# Altium schematic sheet Sheet_Power_v1.SchDoc(Sheet_Power_v1)
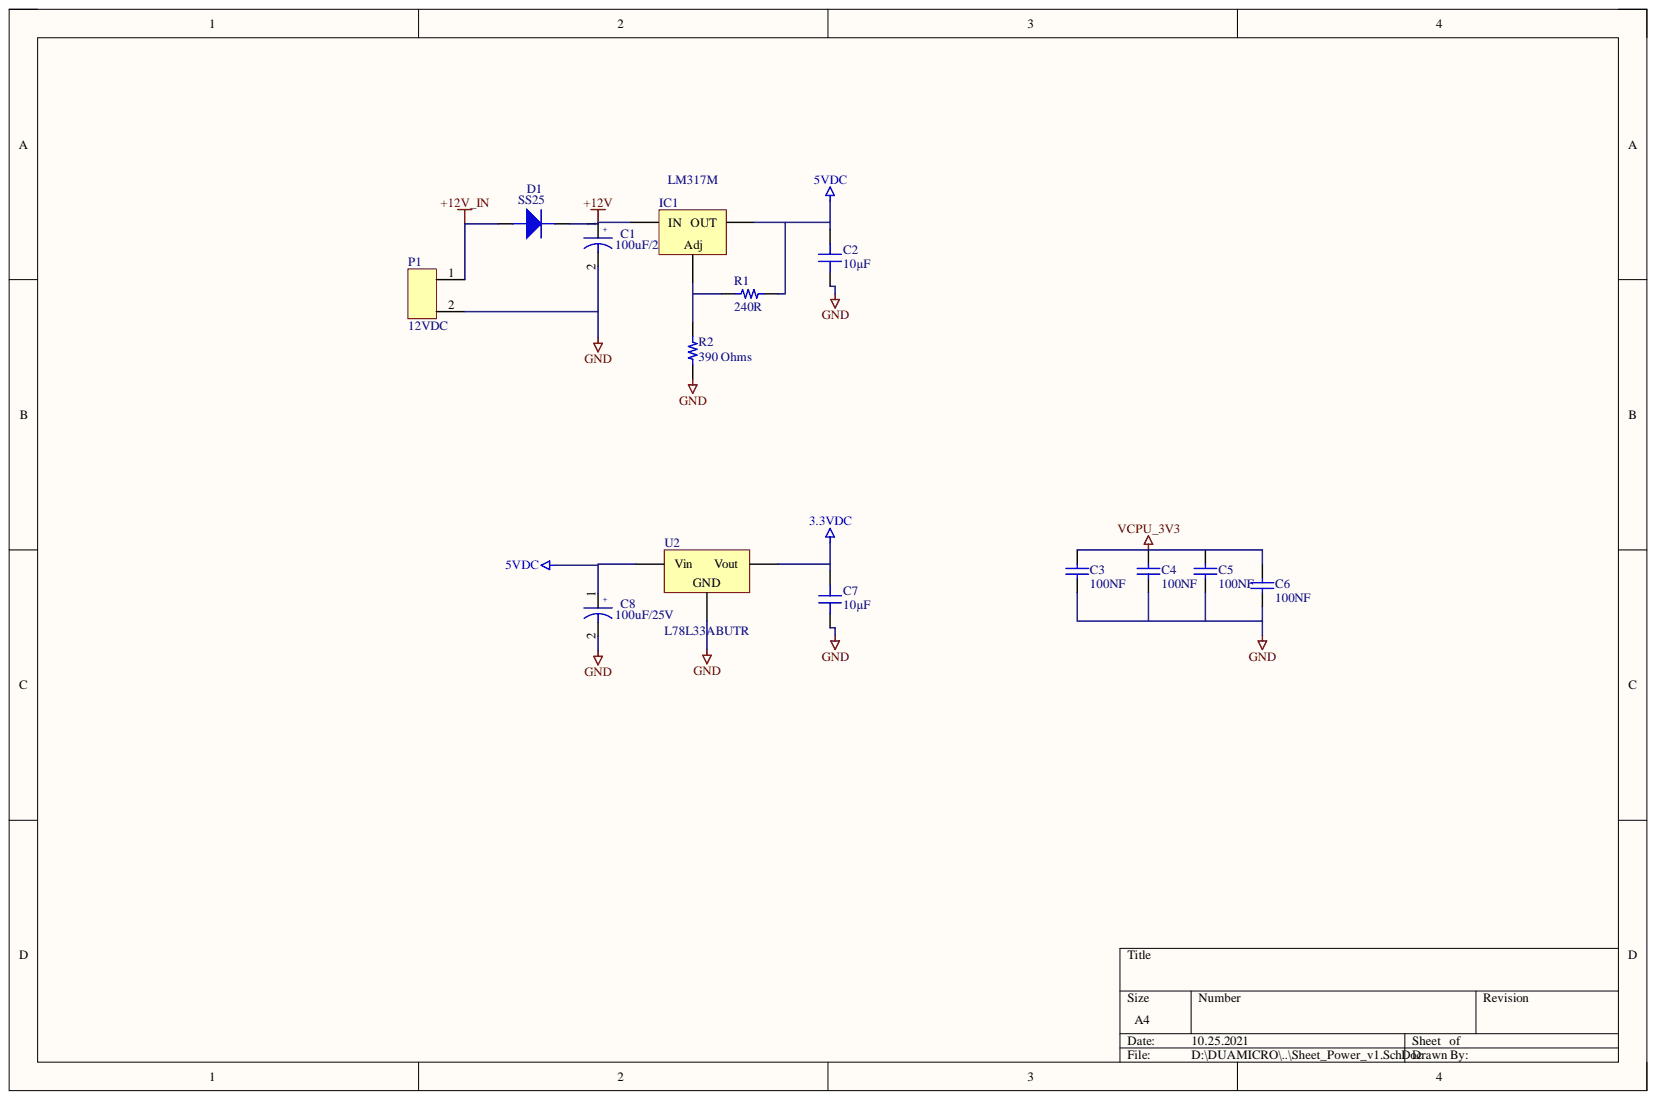
[diagram p0: Sheet_Power_v1.SchDoc]

## Parts
C1  100uF/25V  [ELK8SMD]
C2  10µF  [CAP 0805_2012]
C3  100NF  [CAP 0603_1608]
C4  100NF  [CAP 0603_1608]
C5  100NF  [CAP 0603_1608]
C6  100NF  [CAP 0603_1608]
C7  10µF  [CAP 0805_2012]
C8  100uF/25V  [ELK8SMD]
D1  SS25  [SM/SMA]
IC1  LM317M  [DPAK - TO252]
P1  12VDC  [KLE2-3.50]
R1  240R  [RES 0603/1608]
R2  390 Ohms  [RES 0603/1608]
U2  L78L33ABUTR  [SOT89M - 2 - inv]

## Nets
3.3VDC: C7.2 U2.3
5VDC: C2.2 C8.1 IC1.O R1.2 U2.1
+12V: C1.1 D1.2 IC1.I
+12V_IN: D1.1 P1.1
GND: C1.2 C2.1 C3.1 C4.1 C5.1 C6.1 C7.1 C8.2 P1.2 R2.1 U2.2
VCPU_3V3: C3.2 C4.2 C5.2 C6.2
NetR1_1: IC1.A R1.1 R2.2

## Library data of the parts
C1 C8 = Cap Pol: Component Kind: Standard; Library Name: Altium_Sch.SchLib
C2 C7 = (no symbol name): CAP CER 10UF 10V X7R 0805; Manufacturer: KEMET; Part Number: C0805X106M8RACAUTO; Tolerance: ±20%; Applications: Automotive, Boardflex Sensitive; Capacitance: 10µF; Component Kind: Standard; ComponentLink1Description: Datasheet; ComponentLink1URL: https://api.kemet.com/component-edge/download/datasheet/C0805X106M8RACAUTO.pdf; ComponentLink2Description: DigiKey Link; ComponentLink2URL: http://digikey.com/product-detail/en/kemet/C0805X106M8RACAUTO/399-15692-1-ND/7427572; Device Package: 0805 (2012 Metric); Features: Soft Termination; LastUpdated: 2.09.2020 01:04:41; Lifecycle Status: Active; Minimum Order: 1; Mounting Type: Surface Mount, MLCC; Operating Temperature: -55°C ~ 125°C; Package / Case: 0805 (2012 Metric); Packaging: TapeAndReel; Part Status: Active; PartId: 2833; Price: 0.23; Ratings: AEC-Q200; Series: SMD Auto X7R Flex; Size / Dimension: 0.079" L x 0.049" W (2.00mm x 1.25mm); Supplier 1: DigiKey; Supplier Part Number 1: 399-15692-1-ND; Temperature Coefficient: X7R; Thickness (Max): 0.057" (1.45mm); Value: 10µF; Voltage - Rated: 10V; Library Name: DualMicrolib.SchLib
C3-C6 = CAP CER 100NF 16V X7R 0603: Manufacturer: Samsung Electro-Mechanics; Part Number: CL10B104KO8NNNC; TemperatureRange: -55°C ~ 125°C; Tolerance: ±10%; Type: General Purpose; Component Kind: Standard; ComponentLink1Description: Datasheet; ComponentLink1URL: http://www.samsungsem.com/kr/support/product-search/mlcc/__icsFiles/afieldfile/2016/08/18/S_CL10B104KO8NNNC.pdf; ComponentLink2Description: Digi-Key listing; ComponentLink2URL: https://www.digikey.com/product-detail/en/samsung-electro-mechanics/CL10B104KO8NNNC/1276-1005-1-ND/3889091; Description: CAP CER 100NF 16V X7R 0603; Device Package: 0603 (1608 Metric); Price: $0.10000; Supplier 1: Digi-Key; Supplier Part Number 1: 1276-1005-1-ND; Value: 100NF; Voltage: 16V; Library Name: DualMicrolib.SchLib
D1 = Diode: Component Kind: Standard; Library Name: Altium_Sch.SchLib
P1 = con2: KF2EDGR-Y-3.5-2P-1G; Component Kind: Standard; Library Name: SchlibTMS.SchLib
R1 = (no symbol name): RES SMD 240R OHM 1% 1/10W 0603; Manufacturer: Yageo; Part Number: AC0603FR-07240RL; PowerRating: 0.1W, 1/10W; TemperatureCoefficient: ±100ppm/°C; TemperatureRange: -55°C ~ 155°C; Component Kind: Standard; ComponentLink1Description: Datasheet; ComponentLink1URL: http://www.yageo.com/documents/recent/PYu-RC_Group_51_RoHS_L_10.pdf; ComponentLink2Description: Digi-Key Listing; ComponentLink2URL: https://www.digikey.com/product-detail/en/yageo/RC0603FR-07681KL/311-681KHRCT-ND/730305; Device Package: 0603 (1608 Metric); Price: $0.1 /min1; Supplier 1: Digi-Key; Supplier Part Number 1: YAG3582TR-ND; Tolerance: ±1%; Value: 240R; Library Name: DualMicrolib.SchLib
R2 = (no symbol name): RES SMD 390R OHM 1% 1/10W 0603; Manufacturer: Yageo; Part Number: RC0603FR-07390RL; PowerRating: 0.1W, 1/10W; TemperatureCoefficient: ±100ppm/°C; TemperatureRange: -55°C ~ 155°C; Component Kind: Standard; ComponentLink1Description: Datasheet; ComponentLink1URL: http://www.yageo.com/documents/recent/PYu-RC_Group_51_RoHS_L_9.pdf; ComponentLink2Description: Digi-Key Listing; ComponentLink2URL: https://www.digikey.com/product-detail/en/yageo/RC0603FR-07330RL/311-330HRCT-ND/730109; Device Package: 0603 (1608 Metric); Price: $0.10000/min1; Supplier 1: Digi-Key; Supplier Part Number 1: 311-330HRCT-ND; Tolerance: ±1%; Value: 390 Ohms; Library Name: DualMicrolib.SchLib
U2 = L78L33ABUTR: IC REG LINEAR 3.3V 100MA SOT89-3; Component Kind: Standard; LatestRevisionDate: 29-May-2009; LatestRevisionNote: IPC-7351 Footprint Added.; PackageDescription: Linear Voltage Regulator IC Positive Fixed 1 Output 100mA SOT-89-3; PackageReference: SOT-89-3; Published: 24-Mar-1999; Library Name: DualMicrolib.SchLib; Publisher: Altium Limited
IC1 = LM317M: Component Kind: Standard; Library Name: Schlib (ASSAN).SchLib
